# Altium schematic sheet 6_I2C_Sensors.SchDoc
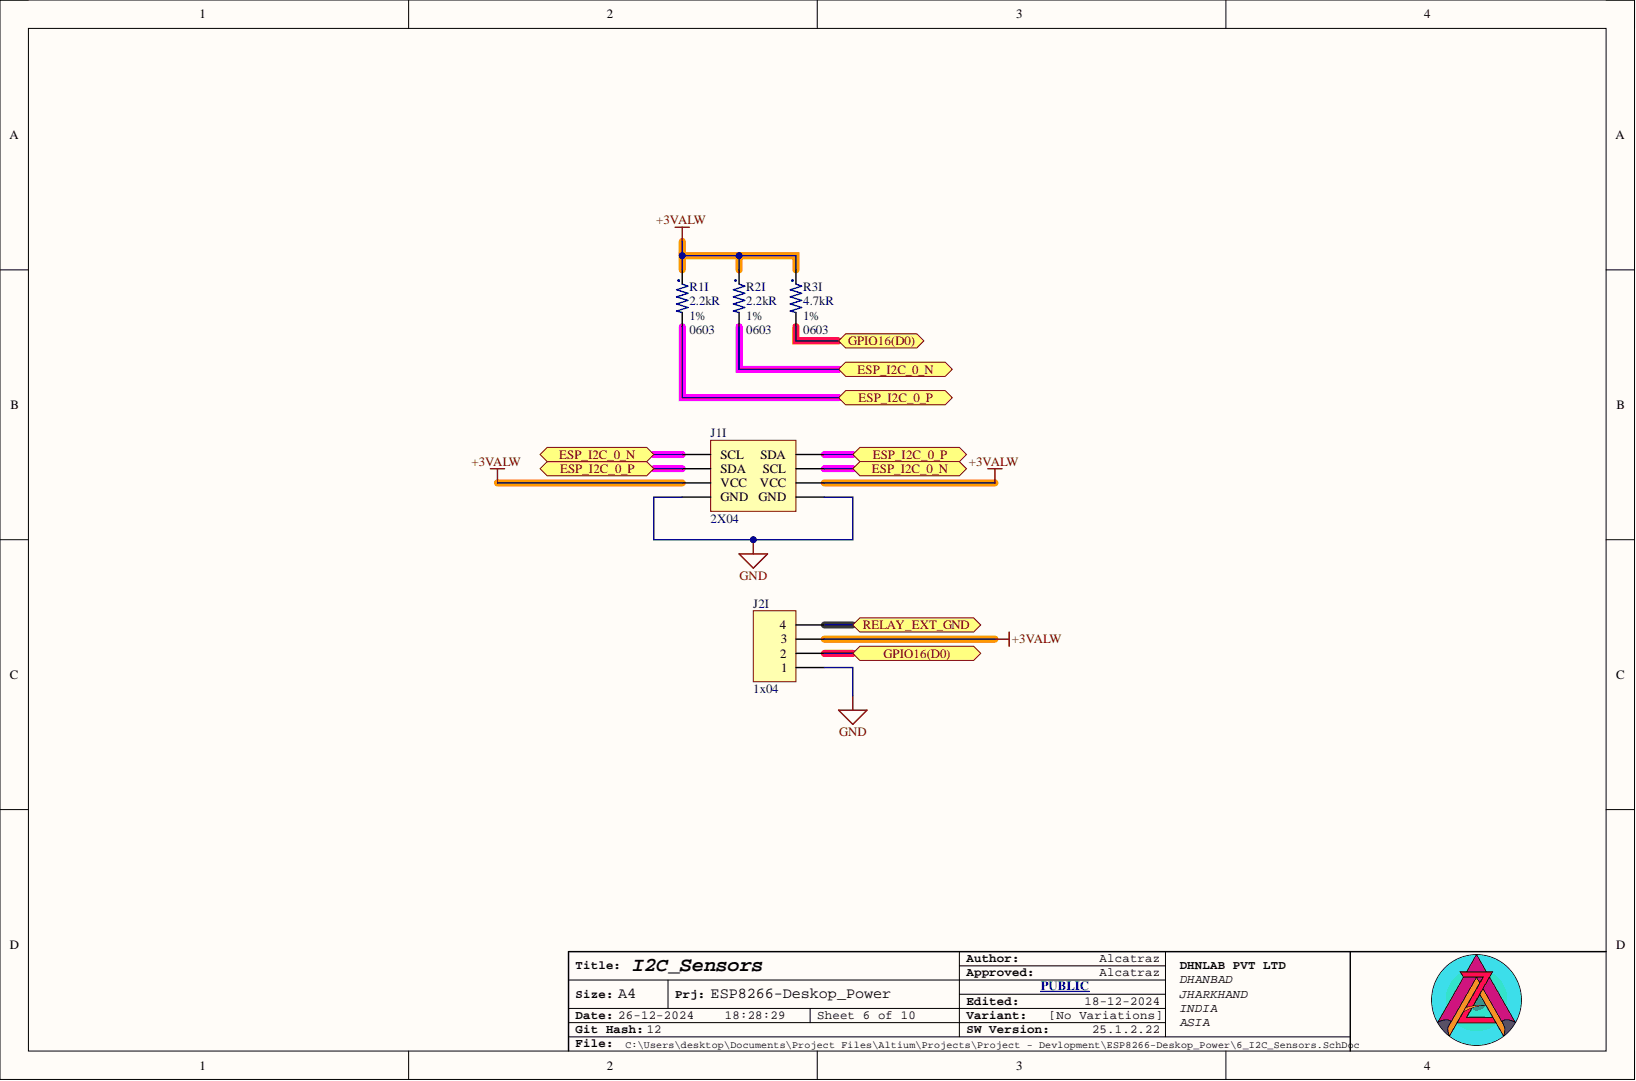
[diagram p0: 6_I2C_Sensors.SchDoc]

## Parts
J1I  2X04  [PinHeader_2x04_P2.54mm_Vertical]
J2I  1x04  [PinHeader_1x04_P2.54mm_Vertical]
R1I  value 2.2kR  Case Code (Imperial): 0603  Resistance Tolerance: 1%  [R0603-MFG]  (hidden comment: RC0603FR-072K2L)
R2I  value 2.2kR  Case Code (Imperial): 0603  Resistance Tolerance: 1%  [R0603-MFG]  (hidden comment: RC0603FR-072K2L)
R3I  value 4.7kR  Case Code (Imperial): 0603  Tolerance: 1%  [R0603-MFG]  (hidden comment: AC0603FR-074K7L)

## Ports
ESP_I2C_0_N, ESP_I2C_0_P, GPIO16(D0), RELAY_EXT_GND

## Nets
+3VALW: J1I.3(VCC) J1I.4(VCC) J2I.3 R1I.1 R2I.1 R3I.1
GND: J1I.1(GND) J1I.2(GND) J2I.1
ESP_I2C_0_N: J1I.5(SCL) J1I.8(SCL) R2I.2 ; port ESP_I2C_0_N
ESP_I2C_0_P: J1I.6(SDA) J1I.7(SDA) R1I.2 ; port ESP_I2C_0_P
GPIO16(D0): J2I.2 R3I.2 ; port GPIO16(D0)
RELAY_EXT_GND: J2I.4 ; port RELAY_EXT_GND

## Library data of the parts
J1I = I2C_2X04_P2.54mm: Component Kind: Standard; Library Name: Lib_Connector_PinHeader_2.54mm.IntLib
J2I = PinHeader 1x04: Component Kind: Standard; Library Name: Lib_Connector_PinHeader_2.54mm.IntLib
R1I R2I = 2.2K: SMD Chip Resistor, 2.2 kOhm, ± 1%, 100 mW, 0603 [1608 Metric], Thick Film, General Purpose; Manufacturer: Yageo; Max Power Dissipation: 100mW; Temperature Coefficient: 100ppm/°C; Voltage Rating: 50V; Case Code (Metric): 1608; Case/Package: 0603; Category: Chip SMD Resistors; Component Kind: Standard; ComponentLink1Description: https://datasheet.ciiva.com/2453/pyu-r-int-thick-7-2453943.pdf?src-supplier=Digikey; ComponentLink1URL: https://datasheet.ciiva.com/2453/pyu-r-int-thick-7-2453943.pdf?src-supplier=Digikey; ComponentLink2Description: https://datasheet.ciiva.com/2453/pyu-r-int-thick-7-2453944.pdf?src-supplier=Digikey; ComponentLink2URL: https://datasheet.ciiva.com/2453/pyu-r-int-thick-7-2453944.pdf?src-supplier=Digikey; ComponentLink3Description: https://datasheet.ciiva.com/2453/pyu-r-int-thick-7-2453945.pdf?src-supplier=Digikey; ComponentLink3URL: https://datasheet.ciiva.com/2453/pyu-r-int-thick-7-2453945.pdf?src-supplier=Digikey; ComponentLink4Description: https://datasheet.ciiva.com/7660/1868787-7660791.pdf?src-supplier=Element14; ComponentLink4URL: https://datasheet.ciiva.com/7660/1868787-7660791.pdf?src-supplier=Element14; ComponentLink5Description: https://datasheet.ciiva.com/46394/443971-46394958.pdf?src-supplier=Element14; ComponentLink5URL: https://datasheet.ciiva.com/46394/443971-46394958.pdf?src-supplier=Element14; Features: Moisture Resistant; Lead Free: Lead Free; Library Name: Lib_Register_0603.IntLib; Manufacturer Part Number: RC0603FR-072K2L; Max Operating Temperature: 155°C; Min Operating Temperature: -55°C; Number of Terminations: 2; Packaging: Tape and Reel; Power Rating: 100mW; Pricing 1: 5000=0.0026, 75000=0.001, 100000=0.0024, 200000=0.001, 375000=0.0023, 500000=0.0009, 1250000=0.0022, 5000000=0.002 (USD); Reach SVHC Compliant: Unknown; Resistor Type: Thick Film; RoHS: Yes; RoHS Compliant: Yes; Series: RC; Stock 1: 6755000; Supplier 1: Future Electronics; Supplier Part Number 1: 6372452; Tolerance: 1%
R3I = 4.7K: SMD Chip Resistor, 4.7 kOhm, ± 1%, 100 mW, 0603 [1608 Metric], Thick Film, General Purpose; Manufacturer: Yageo; Max Power Dissipation: 100mW; Temperature Coefficient: 100ppm/°C; Voltage Rating: 50V; Case Code (Metric): 1608; Case/Package: 0603; Category: Chip SMD Resistors; Component Kind: Standard; ComponentLink1Description: https://datasheet.octopart.com/AC0603FR-074K7L-Yageo-datasheet-166720450.pdf?src-supplier=Future+Electronics; ComponentLink1URL: https://datasheet.octopart.com/AC0603FR-074K7L-Yageo-datasheet-166720450.pdf?src-supplier=Future+Electronics; ComponentLink2Description: http://www.yageo.com/NewPortal/yageodocoutput?fileName=/pdf/R-Chip/PYu-AC_51_RoHS_L_6.pdf&src-supplier=Mouser; ComponentLink2URL: http://www.yageo.com/NewPortal/yageodocoutput?fileName=/pdf/R-Chip/PYu-AC_51_RoHS_L_6.pdf&src-supplier=Mouser; ComponentLink3Description: http://www.yageo.com/documents/recent/PYu-AC_51_RoHS_L_2_revised.pdf?src-supplier=Future+Electronics; ComponentLink3URL: http://www.yageo.com/documents/recent/PYu-AC_51_RoHS_L_2_revised.pdf?src-supplier=Future+Electronics; ComponentLink4Description: http://www.yageo.com/NewPortal/yageodocoutput?fileName=/pdf/R-Chip/PYu-AC_51_RoHS_L_6.pdf&src-supplier=TTI; ComponentLink4URL: http://www.yageo.com/NewPortal/yageodocoutput?fileName=/pdf/R-Chip/PYu-AC_51_RoHS_L_6.pdf&src-supplier=TTI; Features: Moisture Resistant,Automotive AEC-Q200; Lead Free: Lead Free; Library Name: Lib_Register_0603.IntLib; Manufacturer Part Number: AC0603FR-074K7L; Max Operating Temperature: 155°C; Min Operating Temperature: -55°C; Number of Terminations: 2; Packaging: Tape and Reel; Power Rating: 100mW; Pricing 1: 5000=0.0033, 25000=0.0031, 125000=0.0029, 250000=0.0028, 750000=0.0026 (USD); Ratings: AEC-Q200; RoHS: Yes; RoHS Compliant: Yes; Series: AC; Stock 1: 3030000; Supplier 1: Future Electronics; Supplier Part Number 1: 2029294; Termination: SMD/SMT
